# Altium schematic sheet PE.SchDoc(PE)
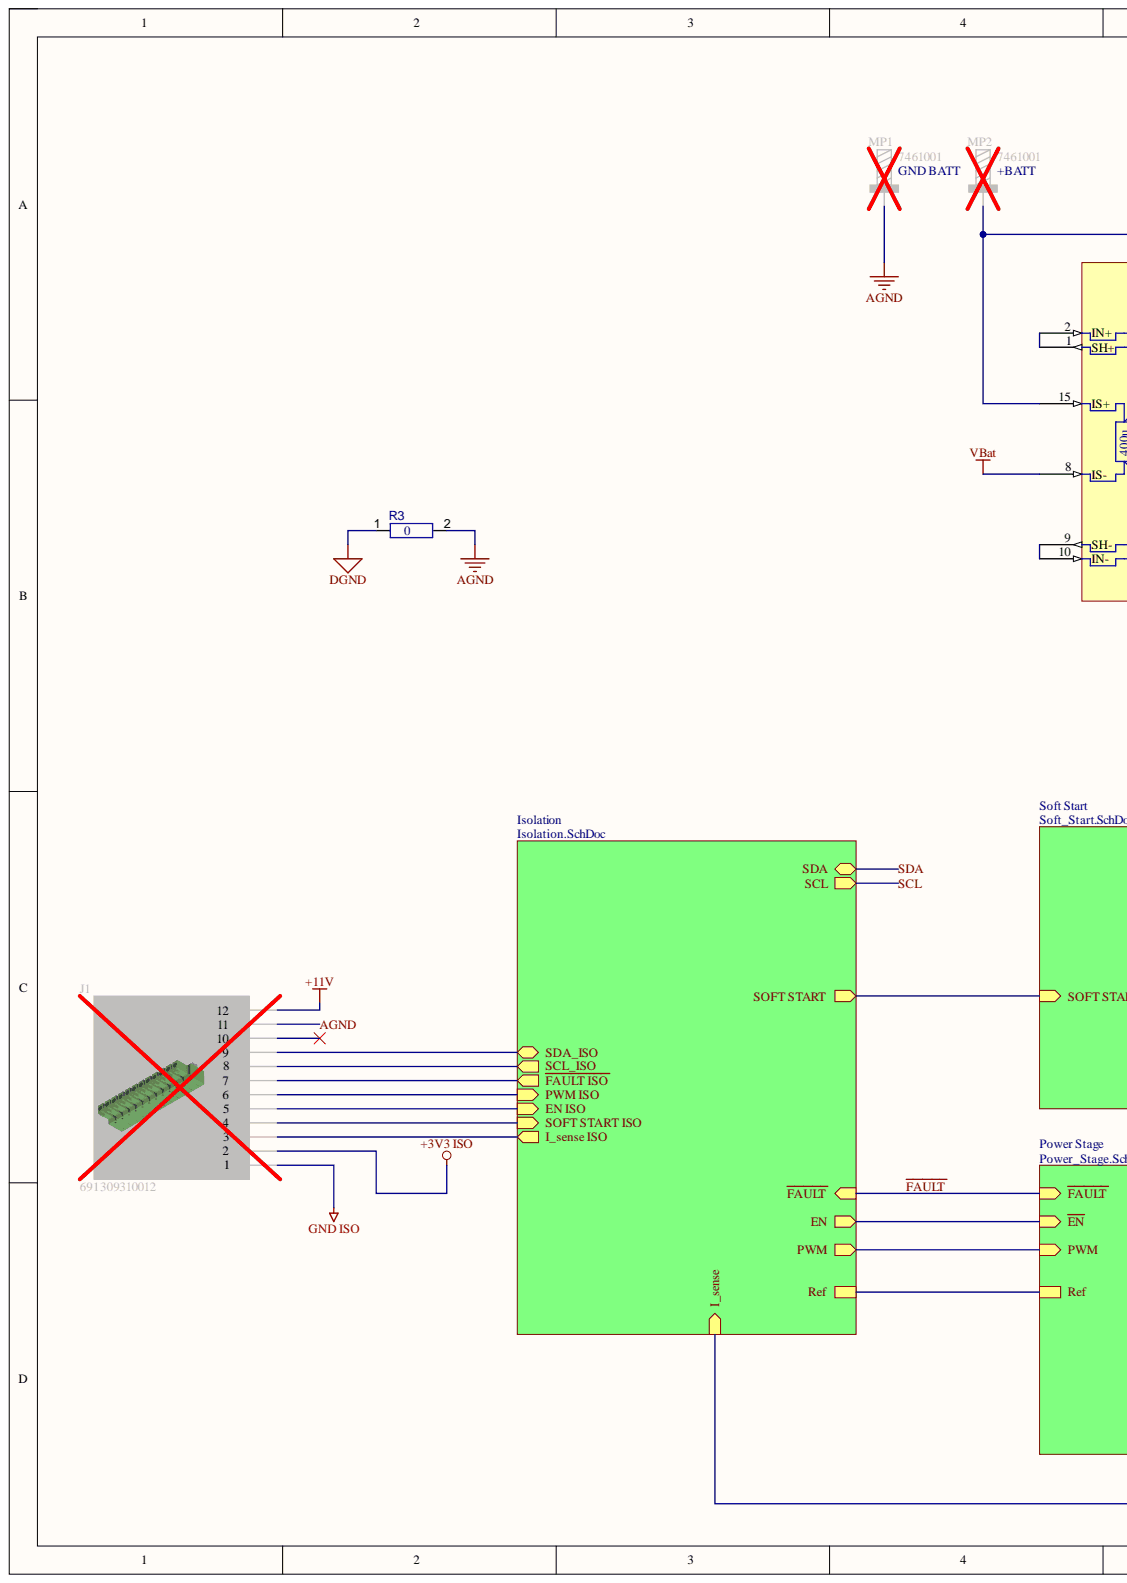
[diagram p0: PE.SchDoc, left]
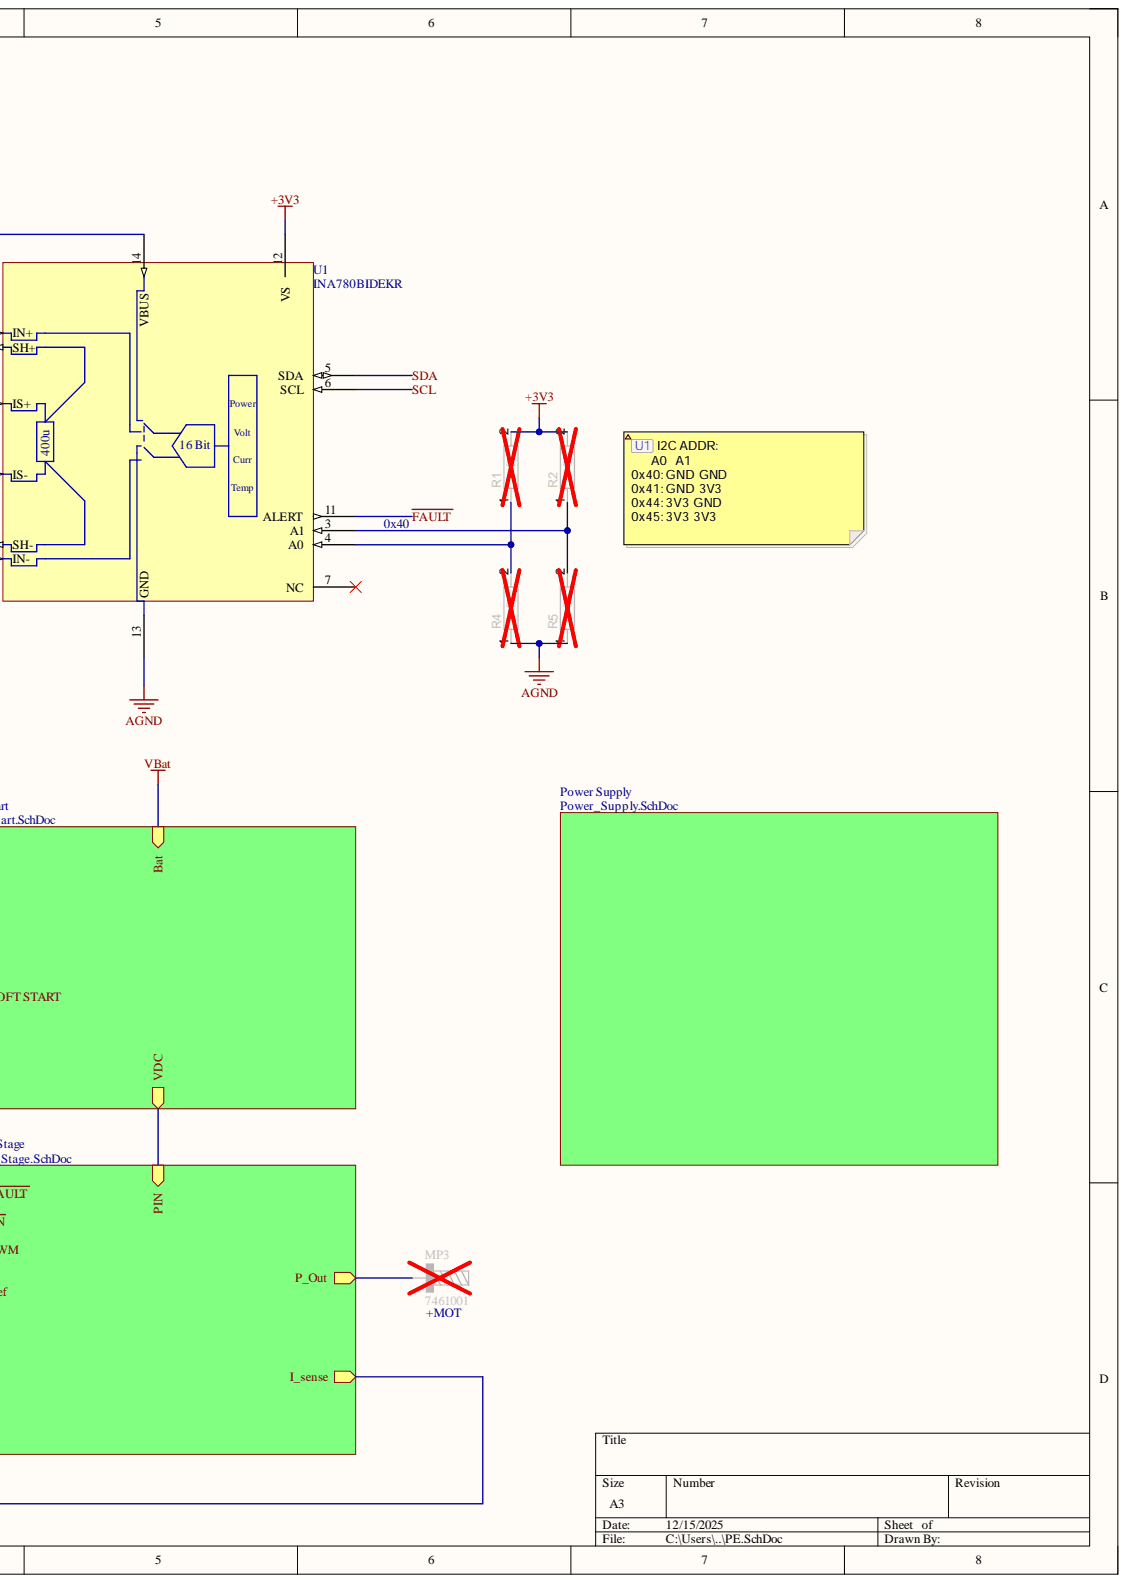
[diagram p1: PE.SchDoc, right]

## Parts
J1  691309310012  [691309310012]
MP1  7461001  [7461001_chemical surface]
MP2  7461001  [7461001_chemical surface]
MP3  7461001  [7461001_chemical surface]
R1  value 0  [RESC3216X60X45NL15T20]  (hidden comment: 1206W4F0000T5E)
R2  value 0  [RESC3216X60X45NL15T20]  (hidden comment: 1206W4F0000T5E)
R3  value 0  [RESC3216X60X45NL15T20]  (hidden comment: 1206W4F0000T5E)
R4  value 0  [RESC3216X60X45NL15T20]  (hidden comment: 1206W4F0000T5E)
R5  value 0  [RESC3216X60X45NL15T20]  (hidden comment: 1206W4F0000T5E)
U1  INA780BIDEKR  [VQFN15_DEK_TEX]

## Nets
+3V3: R1.2 R2.2 U1.12(VS)
+3V3 ISO: J1.2
+11V: J1.12
DGND: R3.1
GND ISO: J1.1
VBat: U1.8(IS-)
~{FAULT}: U1.11(ALERT)
AGND: J1.11 MP1.1 R3.2 R4.1 R5.1 U1.13(GND)
SCL: U1.6(SCL)
SDA: U1.5(SDA)
NetJ1_3: J1.3
NetJ1_4: J1.4
NetJ1_5: J1.5
NetJ1_6: J1.6
NetJ1_7: J1.7
NetJ1_8: J1.8
NetJ1_9: J1.9
NetJ1_10: J1.10
NetMP2_1: MP2.1 U1.14(VBUS) U1.15(IS+)
NetMP3_1: MP3.1
NetR1_1: R1.1 R4.2 U1.4(A0)
NetR2_1: R2.1 R5.2 U1.3(A1)
NetU1_1: U1.1(SH+) U1.2(IN+)
NetU1_7: U1.7(NC)
NetU1_9: U1.9(SH-) U1.10(IN-)
~{EN}:
I_sense:
PIN:
PWM:
Ref:
SOFT START:

## Library data of the parts
J1 = CMP-002-00074-2: Terminal Block, 3.81MM Pitch, Horizontal With Coding Finger, Tht, 12 Pins; Gender: Female; System: Connector; Component Kind: Standard; Library Name: University of Galway
MP1-MP3 = CMP-002-00075-1: Pcb Terminal, Brass, Press-Fit Rohs Compliant: Yes; Current Rating: 130A; Component Kind: Standard; Height: 12mm; Material: Brass; Max Operating Temperature: 150°C; Min Operating Temperature: -55°C; Pins: 9; Library Name: University of Galway
R1-R5 = CMP-009-00183-1: Thick Film Resistors - SMD RMC 1206 1/4W 1% T/R-5000; Case/Package: 1206; Component Kind: Standard; JLCPN: C17888; Library Name: University of Galway
U1 = CMP-004-000000-6: 85-V 16-bit I²C-output digital power monitor with 400-ÂµOhm EZShunt Technology 15-VQFN -40 to 125; Manufacturer_Name: Texas Instruments; Manufacturer_Part_Number: PINA780BIDEKR; Case/Package: VQFN; Component Kind: Standard; Datasheet: https://www.ti.com/lit/gpn/ina780b; Design Item ID: PINA780BIDEKR; Pins: 15; RefDes: RefDes; Source: PINA780BIDEKR.SchLib; Type: Linear; Copyright: Copyright (C) 2025 Ultra Librarian. All rights reserved.; Library Name: University of Galway
